# Altium schematic sheet SH3-CONTROLS.SchDoc
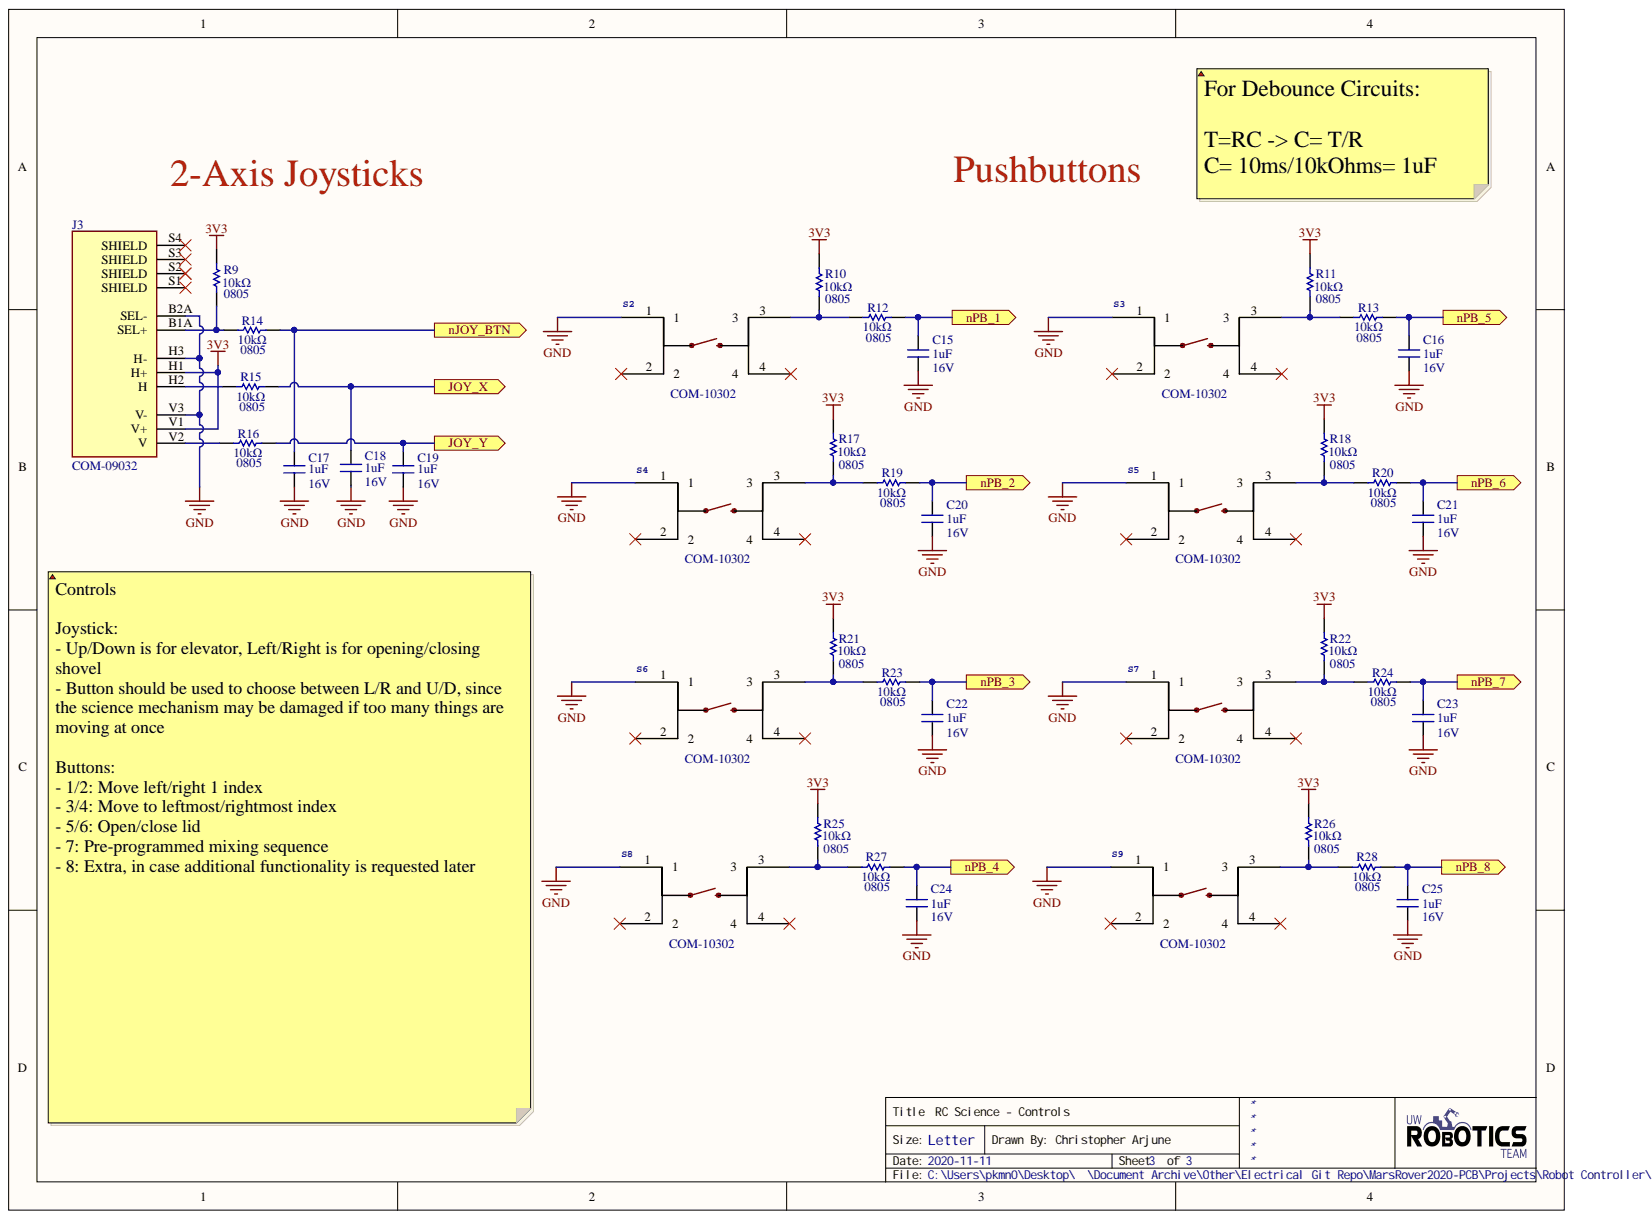
[diagram p0: SH3-CONTROLS.SchDoc]

## Parts
C15  value 1uF  Case Code (Imperial): 0805  Voltage Rating: 16V  [CAPC2012X140N]  (hidden comment: CAP_1uF_16V_0805)
C16  value 1uF  Voltage Rating: 16V  [CAPC2012X140N]  (hidden comment: CAP_1uF_16V_0805)
C17  value 1uF  Voltage Rating: 16V  [CAPC2012X140N]  (hidden comment: CAP_1uF_16V_0805)
C18  value 1uF  Voltage Rating: 16V  [CAPC2012X140N]  (hidden comment: CAP_1uF_16V_0805)
C19  value 1uF  Voltage Rating: 16V  [CAPC2012X140N]  (hidden comment: CAP_1uF_16V_0805)
C20  value 1uF  Case Code (Imperial): 0805  Voltage Rating: 16V  [CAPC2012X140N]  (hidden comment: CAP_1uF_16V_0805)
C21  value 1uF  Case Code (Imperial): 0805  Voltage Rating: 16V  [CAPC2012X140N]  (hidden comment: CAP_1uF_16V_0805)
C22  value 1uF  Case Code (Imperial): 0805  Voltage Rating: 16V  [CAPC2012X140N]  (hidden comment: CAP_1uF_16V_0805)
C23  value 1uF  Case Code (Imperial): 0805  Voltage Rating: 16V  [CAPC2012X140N]  (hidden comment: CAP_1uF_16V_0805)
C24  value 1uF  Case Code (Imperial): 0805  Voltage Rating: 16V  [CAPC2012X140N]  (hidden comment: CAP_1uF_16V_0805)
C25  value 1uF  Voltage Rating: 16V  [CAPC2012X140N]  (hidden comment: CAP_1uF_16V_0805)
J3  Manufacturer Part Number 1: COM-09032  [2_AXIS_JOY]  (hidden comment: 2_AXIS_JOYSTICK)
R9  value 10kΩ  Case Code (Imperial): 0805  [RESC2012X60N]  (hidden comment: RES_10kΩ_0805)
R10  value 10kΩ  Case Code (Imperial): 0805  [RESC2012X60N]  (hidden comment: RES_10kΩ_0805)
R11  value 10kΩ  Case Code (Imperial): 0805  [RESC2012X60N]  (hidden comment: RES_10kΩ_0805)
R12  value 10kΩ  Case Code (Imperial): 0805  [RESC2012X60N]  (hidden comment: RES_10kΩ_0805)
R13  value 10kΩ  Case Code (Imperial): 0805  [RESC2012X60N]  (hidden comment: RES_10kΩ_0805)
R14  value 10kΩ  Case Code (Imperial): 0805  [RESC2012X60N]  (hidden comment: RES_10kΩ_0805)
R15  value 10kΩ  Case Code (Imperial): 0805  [RESC2012X60N]  (hidden comment: RES_10kΩ_0805)
R16  value 10kΩ  Case Code (Imperial): 0805  [RESC2012X60N]  (hidden comment: RES_10kΩ_0805)
R17  value 10kΩ  Case Code (Imperial): 0805  [RESC2012X60N]  (hidden comment: RES_10kΩ_0805)
R18  value 10kΩ  Case Code (Imperial): 0805  [RESC2012X60N]  (hidden comment: RES_10kΩ_0805)
R19  value 10kΩ  Case Code (Imperial): 0805  [RESC2012X60N]  (hidden comment: RES_10kΩ_0805)
R20  value 10kΩ  Case Code (Imperial): 0805  [RESC2012X60N]  (hidden comment: RES_10kΩ_0805)
R21  value 10kΩ  Case Code (Imperial): 0805  [RESC2012X60N]  (hidden comment: RES_10kΩ_0805)
R22  value 10kΩ  Case Code (Imperial): 0805  [RESC2012X60N]  (hidden comment: RES_10kΩ_0805)
R23  value 10kΩ  Case Code (Imperial): 0805  [RESC2012X60N]  (hidden comment: RES_10kΩ_0805)
R24  value 10kΩ  Case Code (Imperial): 0805  [RESC2012X60N]  (hidden comment: RES_10kΩ_0805)
R25  value 10kΩ  Case Code (Imperial): 0805  [RESC2012X60N]  (hidden comment: RES_10kΩ_0805)
R26  value 10kΩ  Case Code (Imperial): 0805  [RESC2012X60N]  (hidden comment: RES_10kΩ_0805)
R27  value 10kΩ  Case Code (Imperial): 0805  [RESC2012X60N]  (hidden comment: RES_10kΩ_0805)
R28  value 10kΩ  Case Code (Imperial): 0805  [RESC2012X60N]  (hidden comment: RES_10kΩ_0805)
S2  Pushbutton  Manufacturer Part Number 1: COM-10302  [TACTILE_SWITCH_PTH_12MM]
S3  Pushbutton  Manufacturer Part Number 1: COM-10302  [TACTILE_SWITCH_PTH_12MM]
S4  Pushbutton  Manufacturer Part Number 1: COM-10302  [TACTILE_SWITCH_PTH_12MM]
S5  Pushbutton  Manufacturer Part Number 1: COM-10302  [TACTILE_SWITCH_PTH_12MM]
S6  Pushbutton  Manufacturer Part Number 1: COM-10302  [TACTILE_SWITCH_PTH_12MM]
S7  Pushbutton  Manufacturer Part Number 1: COM-10302  [TACTILE_SWITCH_PTH_12MM]
S8  Pushbutton  Manufacturer Part Number 1: COM-10302  [TACTILE_SWITCH_PTH_12MM]
S9  Pushbutton  Manufacturer Part Number 1: COM-10302  [TACTILE_SWITCH_PTH_12MM]

## Ports
JOY_X, JOY_Y, nJOY_BTN, nPB_1, nPB_2, nPB_3, nPB_4, nPB_5, nPB_6, nPB_7, nPB_8

## Nets
3V3: J3.H1(H+) J3.V1(V+) R9.1 R10.1 R11.1 R17.1 R18.1 R21.1 R22.1 R25.1 R26.1
GND: C15.1 C16.1 C17.1 C18.1 C19.1 C20.1 C21.1 C22.1 C23.1 C24.1 C25.1 J3.B2A(SEL-) J3.H3(H-) J3.V3(V-) S2.1 S3.1 S4.1 S5.1 S6.1 S7.1 S8.1 S9.1
JOY_X: C18.2 R15.2 ; port JOY_X
JOY_Y: C19.2 R16.2 ; port JOY_Y
nJOY_BTN: C17.2 R14.2 ; port nJOY_BTN
nPB_1: C15.2 R12.2 ; port nPB_1
nPB_2: C20.2 R19.2 ; port nPB_2
nPB_3: C22.2 R23.2 ; port nPB_3
nPB_4: C24.2 R27.2 ; port nPB_4
nPB_5: C16.2 R13.2 ; port nPB_5
nPB_6: C21.2 R20.2 ; port nPB_6
nPB_7: C23.2 R24.2 ; port nPB_7
nPB_8: C25.2 R28.2 ; port nPB_8
NetJ3_B1A: J3.B1A(SEL+) R9.2 R14.1
NetJ3_H2: J3.H2(H) R15.1
NetJ3_V2: J3.V2(V) R16.1
NetR10_2: R10.2 R12.1 S2.3
NetR11_2: R11.2 R13.1 S3.3
NetR17_2: R17.2 R19.1 S4.3
NetR18_2: R18.2 R20.1 S5.3
NetR21_2: R21.2 R23.1 S6.3
NetR22_2: R22.2 R24.1 S7.3
NetR25_2: R25.2 R27.1 S8.3
NetR26_2: R26.2 R28.1 S9.3

Not connected: J3 S1(SHIELD) S2(SHIELD) S3(SHIELD) S4(SHIELD); S2 2 4; S3 2 4; S4 2 4; S5 2 4; S6 2 4; S7 2 4; S8 2 4; S9 2 4

## Library data of the parts
C15-C25 = CAP_1uF_16V_0805: CAP CER 1UF 16V X7R 0805; Manufacturer 1: Yageo; Manufacturer Part Number 1: CC0805KKX7R7BB105; Tolerance: 10%; Case Code (Metric): 2012; Component Kind: Standard; Dielectric: X7R; Max Operating Temperature: 125°C; Min Operating Temperature: -55°C; Mount: Surface Mount; Package: 0805 (2012 Metric); Supplier 1: Digi-Key; Supplier Part Number 1: 311-1365-1-ND; Termination: SMD/SMT; Library Name: UWRT_Schematic_Library_2020.SchLib
  C16-C19 C25: Case Code (Imperial): 0805
J3 = 2_AXIS_JOYSTICK: JOYSTICK 10K OHM 2 AXIS TH; Manufacturer 1: SparkFun; Component Kind: Standard; ComponentLink1Description: https://media.digikey.com/pdf/Data%20Sheets/Sparkfun%20PDFs/COM-09032_Web.pdf?src-supplier=Digi-Key; ComponentLink1URL: https://media.digikey.com/pdf/Data%20Sheets/Sparkfun%20PDFs/COM-09032_Web.pdf?src-supplier=Digi-Key; Pricing 1: 1=3.95000 (USD); RoHS: No; Stock 1: 1039; Supplier 1: Digi-Key; Supplier Part Number 1: 1568-1526-ND; Library Name: UWRT_Schematic_Library_2020.SchLib
R9-R28 = RES_10kΩ_0805: RES SMD 10K OHM 1% 1/8W 0805; Manufacturer 1: Yageo; Manufacturer Part Number 1: RC0805FR-0710KL; Power Rating: 125mW; Case Code (Metric): 2012; Component Kind: Standard; Max Operating Temperature: 155°C; Min Operating Temperature: -55°C; Package: 0805 (2012 Metric); Resistance: 10K; Supplier 1: Digi-Key; Supplier Part Number 1: 311-10.0KCRCT-ND; Termination: SMD/SMT; Tolerance: 1%; Library Name: UWRT_Schematic_Library_2020.SchLib
S2-S9 = MOMENTARY_SWITCH_TH: Momentary Switch (Pushbutton) - SPST; Manufacturer 1: SparkFun; PROD_ID: SWCH-09185; SF_SKU: COM-09190; SymbolName_1: SWITCH-MOMENTARY-2; Component Kind: Standard; ComponentLink1Description: https://www.sparkfun.com/datasheets/Components/Buttons/TSA12110%20TACT%20SWITCH.jpg?src-supplier=Digi-Key; ComponentLink1URL: https://www.sparkfun.com/datasheets/Components/Buttons/TSA12110%20TACT%20SWITCH.jpg?src-supplier=Digi-Key; Mount: Through Hole; Package: TACTILE_SWITCH_PTH_12MM; Pricing 1: 1=5.85000 (USD); RoHS: No; Stock 1: 32; Supplier 1: Digi-Key; Supplier Part Number 1: 1568-1332-ND; Termination: PC Pin; Throw Configuration: SPST; Library Name: UWRT_Schematic_Library_2020.SchLib
